# Altium schematic sheet 新版机械臂扩展板.SchDoc
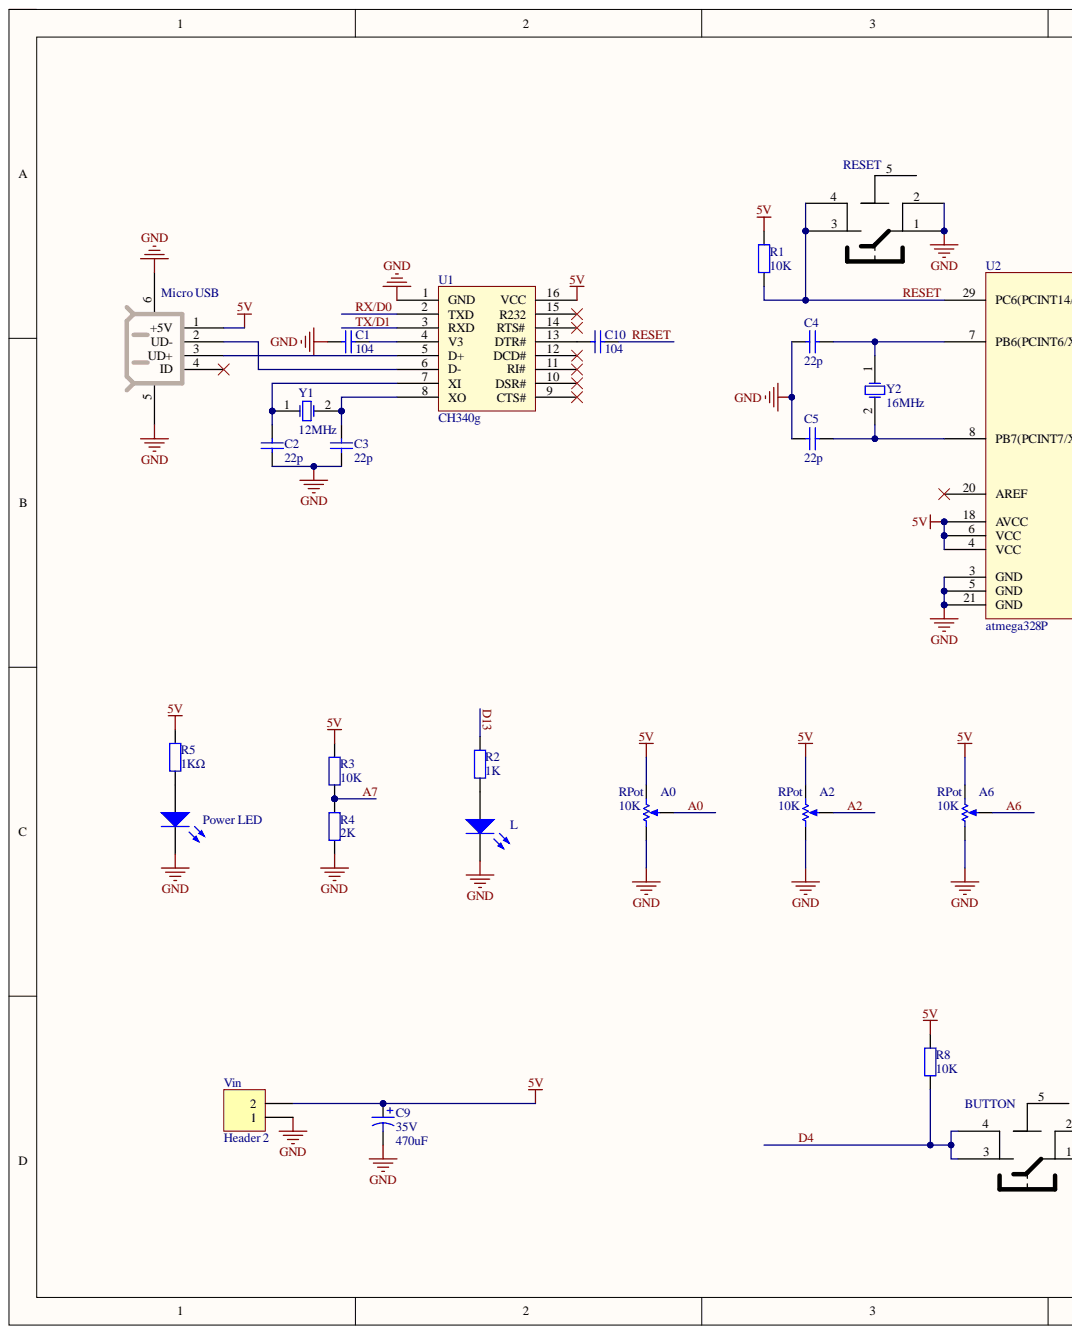
[diagram p0: 新版机械臂扩展板.SchDoc, left]
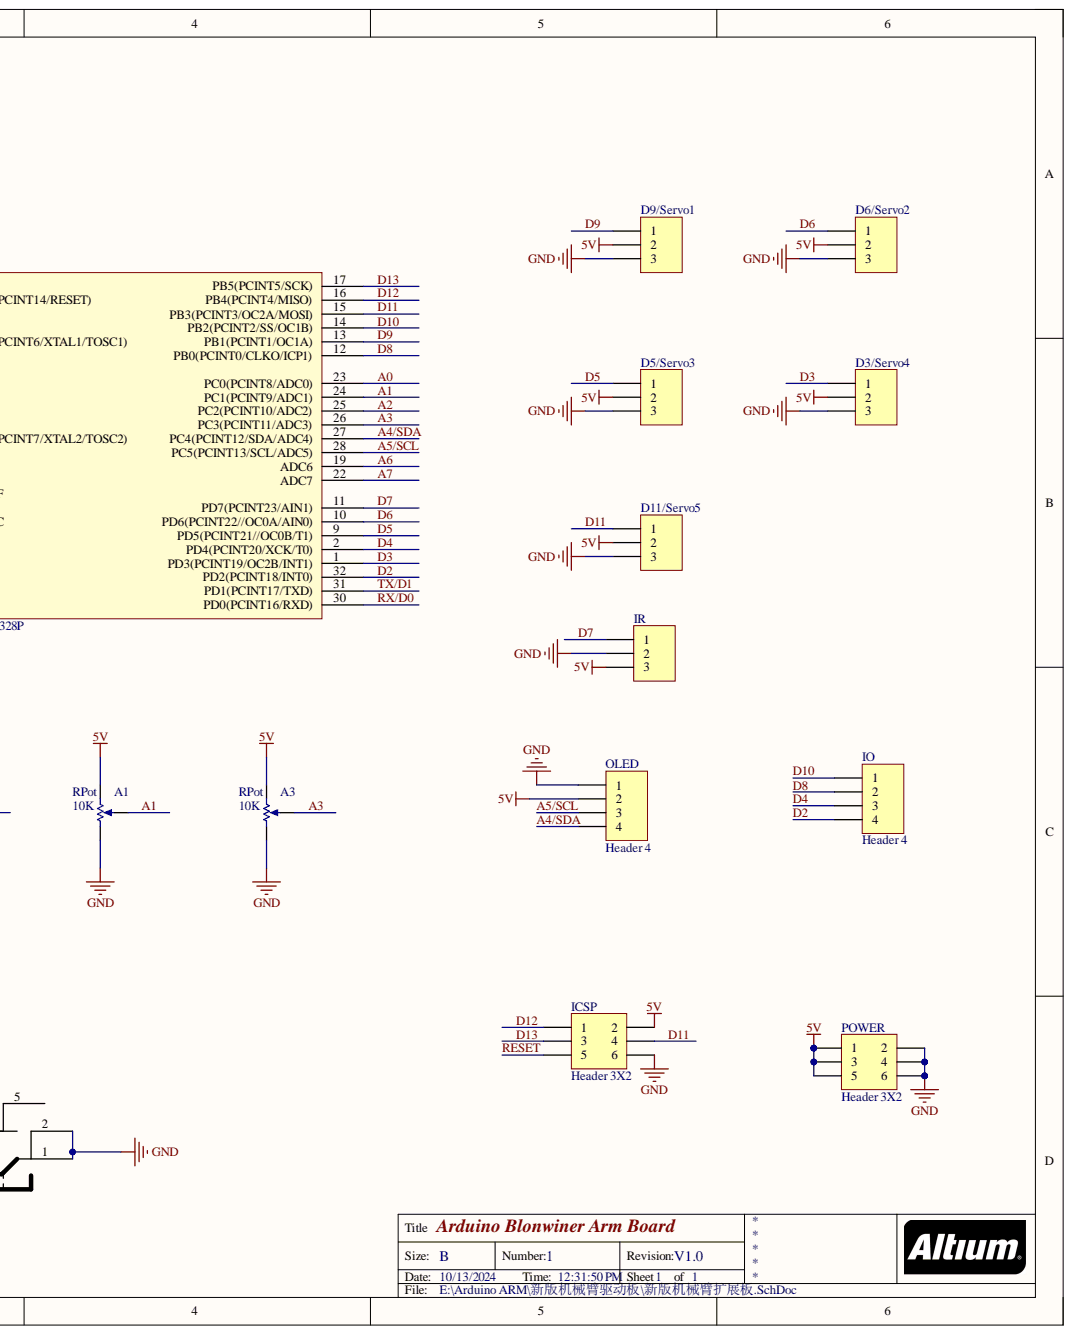
[diagram p1: 新版机械臂扩展板.SchDoc, right]

## Parts
A0  RPot  value 10K  [电位器]
A1  RPot  value 10K  [电位器]
A2  RPot  value 10K  [电位器]
A3  RPot  value 10K  [电位器]
A6  RPot  value 10K  [电位器]
BUTTON  Button  [RESET]  (value not drawn)
C1  value 104  [0805]  (hidden comment: Cap)
C2  value 22p  [0805]  (hidden comment: Cap)
C3  value 22p  [0805]  (hidden comment: Cap)
C4  value 22p  [0805]  (hidden comment: Cap)
C5  value 22p  [0805]  (hidden comment: Cap)
C9  35V  value 470uF  [470uF/35V]
C10  value 104  [0805]  (hidden comment: Cap)
D3/Servo4  Servo4  [HDR1X3]
D5/Servo3  Servo3  [HDR1X3]
D6/Servo2  Servo2  [HDR1X3]
D9/Servo1  Servo1  [HDR1X3]
D11/Servo5  Servo3  [HDR1X3]  (value not drawn)
ICSP  Header 3X2  [HDR2X3]
IO  Header 4  [HDR1X4]
IR  Servo3  [HDR1X3]  (value not drawn)
L  LED3  [LED0805]  (value not drawn)
Micro_USB  Micro USB  [MicroH]
OLED  Header 4  [HDR1X4]
POWER  Header 3X2  [HDR2X3]
Power_LED  LED  [LED0805]
R1  value 10K  [0805]  (hidden comment: Res2)
R2  value 1K  [0805]  (hidden comment: Res2)
R3  value 10K  [0805]  (hidden comment: Res2)
R4  value 2K  [0805]  (hidden comment: Res2)
R5  value 1KΩ  [0805]  (hidden comment: Res2)
R8  value 10K  [0805]  (hidden comment: Res2)
RESET  Button  [RESET]  (value not drawn)
U1  CH340g  [SO16]
U2  atmega328P  [Atmega328P]
Vin  Header 2  [Patch2Pin]
Y1  12MHz  [CY_2S]
Y2  16MHz  [CY_2S]

## Nets
5V: A0.2 A1.2 A2.2 A3.2 A6.2 C9.1 D3/Servo4.2 D5/Servo3.2 D6/Servo2.2 D9/Servo1.2 D11/Servo5.2 ICSP.2 IR.3 Micro_USB.1(+5V) OLED.2 POWER.1 POWER.3 POWER.5 R1.2 R3.2 R5.2 R8.2 U1.16(VCC) U2.4(VCC) U2.6(VCC) U2.18(AVCC) Vin.2
GND: A0.1 A1.1 A2.1 A3.1 A6.1 BUTTON.1 BUTTON.2 C1.2 C2.2 C3.2 C4.2 C5.2 C9.2 D3/Servo4.3 D5/Servo3.3 D6/Servo2.3 D9/Servo1.3 D11/Servo5.3 ICSP.6 IR.2 L.2 Micro_USB.5 Micro_USB.6 OLED.1 POWER.2 POWER.4 POWER.6 Power_LED.2 R4.1 RESET.1 RESET.2 U1.1(GND) U2.3(GND) U2.5(GND) U2.21(GND) Vin.1
A0: A0.3 U2.23(PC0(PCINT8/ADC0))
A1: A1.3 U2.24(PC1(PCINT9/ADC1))
A2: A2.3 U2.25(PC2(PCINT10/ADC2))
A3: A3.3 U2.26(PC3(PCINT11/ADC3))
A4/SDA: OLED.4 U2.27(PC4(PCINT12/SDA/ADC4))
A5/SCL: OLED.3 U2.28(PC5(PCINT13/SCL/ADC5))
A6: A6.3 U2.19(ADC6)
A7: R3.1 R4.2 U2.22(ADC7)
D2: IO.4 U2.32(PD2(PCINT18/INT0))
D3: D3/Servo4.1 U2.1(PD3(PCINT19/OC2B/INT1))
D4: BUTTON.3 BUTTON.4 IO.3 R8.1 U2.2(PD4(PCINT20/XCK/T0))
D5: D5/Servo3.1 U2.9(PD5(PCINT21//OC0B/T1))
D6: D6/Servo2.1 U2.10(PD6(PCINT22//OC0A/AIN0))
D7: IR.1 U2.11(PD7(PCINT23/AIN1))
D8: IO.2 U2.12(PB0(PCINT0/CLKO/ICP1))
D9: D9/Servo1.1 U2.13(PB1(PCINT1/OC1A))
D10: IO.1 U2.14(PB2(PCINT2/SS/OC1B))
D11: D11/Servo5.1 ICSP.4 U2.15(PB3(PCINT3/OC2A/MOSI))
D12: ICSP.1 U2.16(PB4(PCINT4/MISO))
D13: ICSP.3 R2.1 U2.17(PB5(PCINT5/SCK))
RESET: C10.1 ICSP.5 R1.1 RESET.3 RESET.4 U2.29(PC6(PCINT14/RESET))
RX/D0: U1.2(TXD) U2.30(PD0(PCINT16/RXD))
TX/D1: U1.3(RXD) U2.31(PD1(PCINT17/TXD))
NetC1_1: C1.1 U1.4(V3)
NetC2_1: C2.1 U1.7(XI) Y1.1
NetC3_1: C3.1 U1.8(XO) Y1.2
NetC4_1: C4.1 U2.7(PB6(PCINT6/XTAL1/TOSC1)) Y2.1
NetC5_1: C5.1 U2.8(PB7(PCINT7/XTAL2/TOSC2)) Y2.2
NetC10_2: C10.2 U1.13(DTR#)
NetL_1: L.1 R2.2
NetMicro USB_2: Micro_USB.2(UD-) U1.6(D-)
NetMicro USB_3: Micro_USB.3(UD+) U1.5(D+)
NetPower LED_1: Power_LED.1 R5.1

Not connected: BUTTON 5; Micro_USB 4(ID); RESET 5; U1 9(CTS#) 10(DSR#) 11(RI#) 12(DCD#) 14(RTS#) 15(R232); U2 20(AREF)

## Library data of the parts
A0-A3 A6 = RPot: Potentiometer; Set Position: 0.5; Sim Note: Enter the Resistance value and the set point into parameter fields.; Component Kind: Standard; LatestRevisionDate: 17-Jul-2002; LatestRevisionNote: Re-released for DXP Platform.; Library Name: Miscellaneous Devices.IntLib; PackageDescription: Variable Resistor, Thru-Hole; 3 Leads; PackageReference: VR5; Published: 8-Jun-2000; Simulation: POT; Publisher: Altium Limited
C1-C5 C10 = Cap: Capacitor; Component Kind: Standard; LatestRevisionDate: 17-Jul-2002; LatestRevisionNote: Re-released for DXP Platform.; Library Name: Miscellaneous Devices.IntLib; PackageReference: RAD-0.3; Published: 8-Jun-2000; Signal Integrity: Cap; Simulation: CAP; Publisher: Altium Limited
C9 = Cap Pol1: Polarized Capacitor (Radial); Component Kind: Standard; LatestRevisionDate: 17-Jul-2002; LatestRevisionNote: Re-released for DXP Platform.; Library Name: Miscellaneous Devices.IntLib; PackageReference: RB7.6-15; Published: 8-Jun-2000; Signal Integrity: Cap Pol; Simulation: CAP; Publisher: Altium Limited
D3/Servo4 D5/Servo3 D6/Servo2 D9/Servo1 D11/Servo5 IR = Header 3: Header, 3-Pin; Component Kind: Standard; LatestRevisionDate: 17-Jul-2002; LatestRevisionNote: Re-released for DXP Platform.; Library Name: Miscellaneous Connectors.IntLib; Signal Integrity: Connector; Publisher: Altium Limited
ICSP POWER = Header 3X2: Header, 3-Pin, Dual row; Component Kind: Standard; LatestRevisionDate: 17-Jul-2002; LatestRevisionNote: Re-released for DXP Platform.; Library Name: Miscellaneous Connectors.IntLib; Signal Integrity: Connector; Publisher: Altium Limited
IO OLED = Header 4: Header, 4-Pin; Component Kind: Standard; LatestRevisionDate: 17-Jul-2002; LatestRevisionNote: Re-released for DXP Platform.; Library Name: Miscellaneous Connectors.IntLib; Signal Integrity: Connector; Publisher: Altium Limited
L = LED3: Typical BLUE SiC LED; Component Kind: Standard; DatasheetVersion: 16-Apr-2002; LatestRevisionDate: 17-Dec-2003; LatestRevisionNote: PCB Footprint replaced.; Library Name: Miscellaneous Devices.IntLib; PackageReference: 3.5X2.8X1.9; PackageVersion: 16-Apr-2002; Published: 8-Jun-2000; Signal Integrity: LED; Simulation: LED3; Publisher: Altium Limited
Micro_USB = Mic: Component Kind: Standard; Library Name: 实验PCB库.SchLib
Power_LED = LED0: Typical INFRARED GaAs LED; Component Kind: Standard; LatestRevisionDate: 08-Jul-2005; LatestRevisionNote: Mech Layer 1 removed.; Library Name: Miscellaneous Devices.IntLib; PackageDescription: LED; 2 Leads; PackageReference: LED-0; Published: 8-Jun-2000; Simulation: LED0; Publisher: Altium Limited
R1-R5 R8 = Res2: Resistor; Component Kind: Standard; LatestRevisionDate: 17-Jul-2002; LatestRevisionNote: Re-released for DXP Platform.; PackageReference: AXIAL-0.4; Published: 8-Jun-2000; Signal Integrity: Res; Simulation: RESISTOR; Publisher: Altium Limited
  R1-R4: Library Name: Miscellaneous Devices.IntLib
  R5: PackageDescription: Axial Device, Thru-Hole; 2 Leads; 0.4 in Pin Spacing; Library Name: 实验PCB库.SchLib
  R8: Library Name: Miscellaneous Devices.IntLib; PackageDescription: Axial Device, Thru-Hole; 2 Leads; 0.4 in Pin Spacing
Vin = Header 2: Header, 2-Pin; Component Kind: Standard; LatestRevisionDate: 17-Jul-2002; LatestRevisionNote: Re-released for DXP Platform.; Library Name: Miscellaneous Connectors.IntLib; Signal Integrity: Connector; Publisher: Altium Limited
Y1 Y2 = XTAL: Crystal Oscillator; Component Kind: Standard; LatestRevisionDate: 17-Jul-2002; LatestRevisionNote: Re-released for DXP Platform.; PackageDescription: Crystal, Thru-Hole; 2 Leads; Body 3.1 x 8.2 mm (Dia.xH); PackageReference: R38; Published: 8-Jun-2000; Simulation: XTAL; Library Name: 实验PCB库.SchLib; Publisher: Altium Limited
BUTTON RESET = Button: Component Kind: Standard; Library Name: Adeept.SchLib
U1 = CH340g: Component Kind: Standard; Library Name: Adeept.SchLib
U2 = atmega328P: Component Kind: Standard; Library Name: Adeept.SchLib
